# Altium schematic sheet 1_POWER.SchDoc
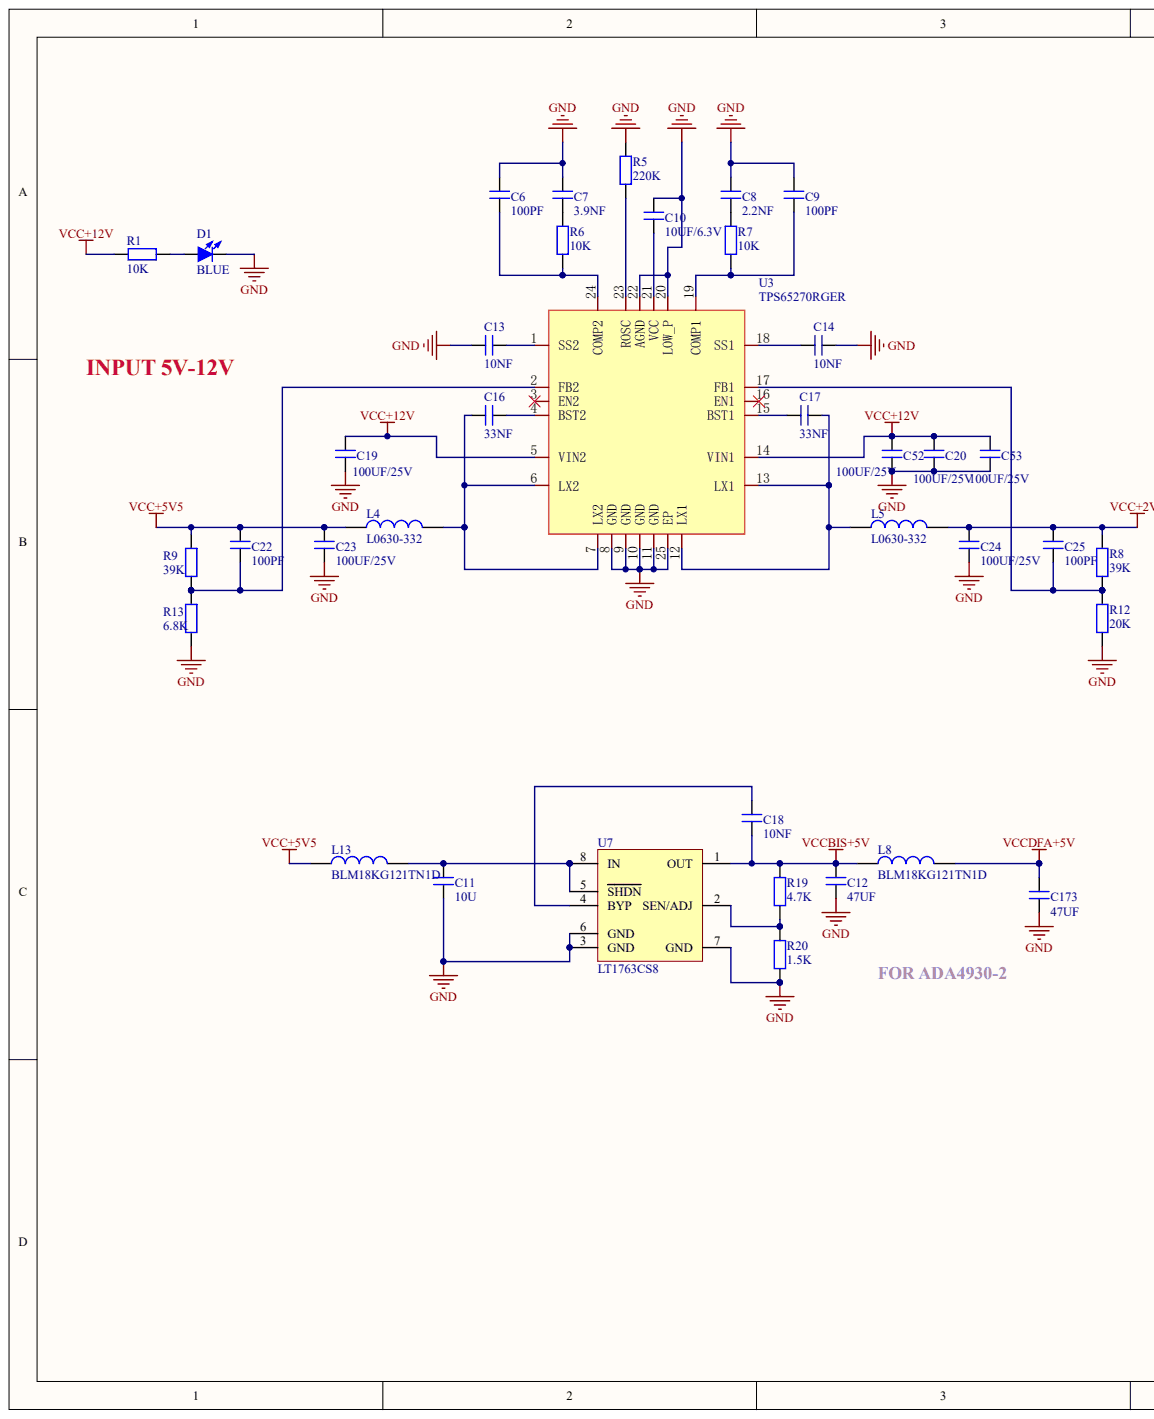
[diagram p0: 1_POWER.SchDoc, left]
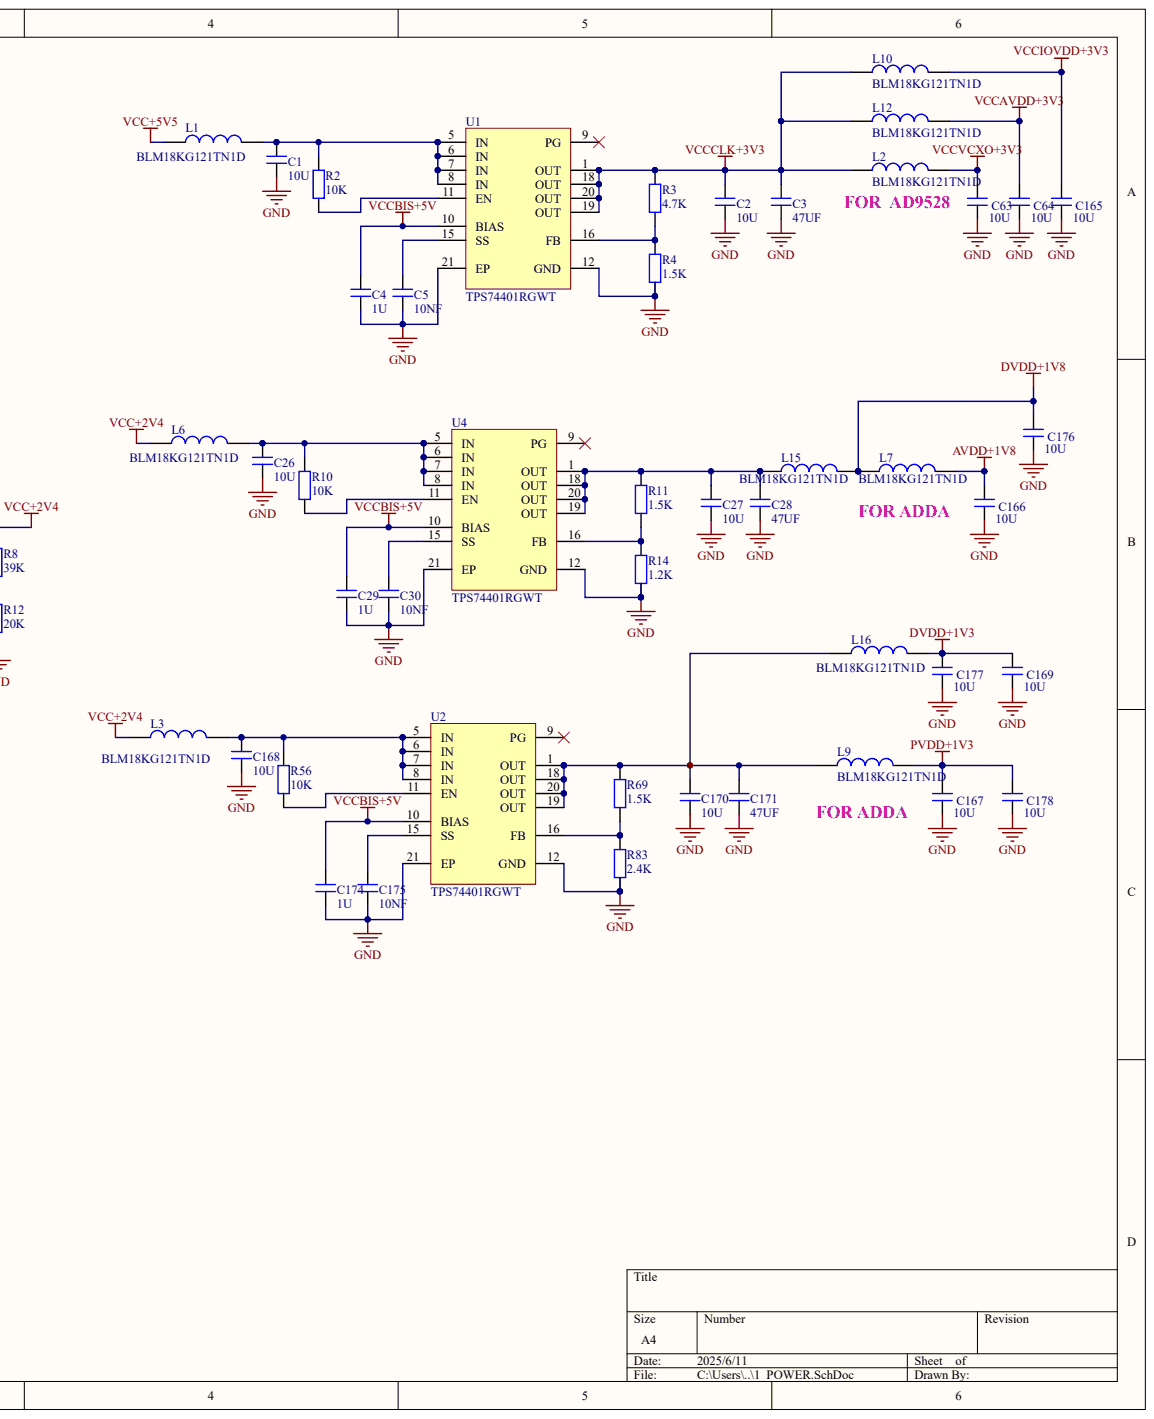
[diagram p1: 1_POWER.SchDoc, right]

## Parts
C1  10U
C2  10U
C3  47UF
C4  1U
C5  10NF
C6  100PF
C7  3.9NF
C8  2.2NF
C9  100PF
C10  10UF/6.3V
C11  10U
C12  47UF
C13  10NF
C14  10NF
C16  33NF
C17  33NF
C18  10NF
C19  100UF/25V
C20  100UF/25V
C22  100PF
C23  100UF/25V
C24  100UF/25V
C25  100PF
C26  10U
C27  10U
C28  47UF
C29  1U
C30  10NF
C52  100UF/25V
C53  100UF/25V
C63  10U
C64  10U
C165  10U
C166  10U
C167  10U
C168  10U
C169  10U
C170  10U
C171  47UF
C173  47UF
C174  1U
C175  10NF
C176  10U
C177  10U
C178  10U
D1  BLUE
L1  BLM18KG121TN1D
L2  BLM18KG121TN1D
L3  BLM18KG121TN1D
L4  L0630-332
L5  L0630-332
L6  BLM18KG121TN1D
L7  BLM18KG121TN1D
L8  BLM18KG121TN1D
L9  BLM18KG121TN1D
L10  BLM18KG121TN1D
L12  BLM18KG121TN1D
L13  BLM18KG121TN1D
L15  BLM18KG121TN1D
L16  BLM18KG121TN1D
R1  10K
R2  10K
R3  4.7K
R4  1.5K
R5  220K
R6  10K
R7  10K
R8  39K
R9  39K
R10  10K
R11  1.5K
R12  20K
R13  6.8K
R14  1.2K
R19  4.7K
R20  1.5K
R56  10K
R69  1.5K
R83  2.4K
U1  TPS74401RGWT
U2  TPS74401RGWT
U3  TPS65270RGER
U4  TPS74401RGWT
U7  LT1763CS8

## Nets
AVDD+1V8: C166.2 L7.2
DVDD+1V3: C169.2 C177.2 L16.2
DVDD+1V8: C176.2 L7.1 L15.2
GND: C1.2 C2.1 C3.1 C4.2 C5.2 C6.2 C7.2 C8.2 C9.2 C10.1 C11.2 C12.1 C13.1 C14.2 C19.1 C20.1 C23.2 C24.2 C26.2 C27.1 C28.1 C29.2 C30.2 C52.1 C53.1 C63.1 C64.1 C165.1 C166.1 C167.1 C168.2 C169.1 C170.1 C171.1 C173.1 C174.2 C175.2 C176.1 C177.1 C178.1 D1.2 R4.1 R5.2 R12.1 R13.1 R14.1 R20.1 R83.1 U1.12(GND) U1.21(EP) U2.12(GND) U2.21(EP) U3.8(GND) U3.9(GND) U3.10(GND) U3.11(GND) U3.20(LOW_P) U3.22(AGND) U3.25(EP) U4.12(GND) U4.21(EP) U7.3(GND) U7.6(GND) U7.7(GND)
PVDD+1V3: C167.2 C178.2 L9.2
VCC+2V4: C24.1 C25.2 L3.1 L5.1 L6.1 R8.2
VCC+5V5: C22.2 C23.1 L1.1 L4.1 L13.1 R9.2
VCC+12V: C19.2 C20.2 C52.2 C53.2 R1.1 U3.5(VIN2) U3.14(VIN1)
VCCAVDD+3V3: C64.2 L12.2
VCCBIS+5V: C4.1 C12.2 C18.1 C29.1 C174.1 L8.1 R19.2 U1.10(BIAS) U2.10(BIAS) U4.10(BIAS) U7.1(OUT)
VCCCLK+3V3: C2.2 C3.2 L2.1 L10.1 L12.1 R3.2 U1.1(OUT) U1.18(OUT) U1.19(OUT) U1.20(OUT)
VCCDFA+5V: C173.2 L8.2
VCCIOVDD+3V3: C165.2 L10.2
VCCVCXO+3V3: C63.2 L2.2
NetC1_1: C1.1 L1.2 R2.2 U1.5(IN) U1.6(IN) U1.7(IN) U1.8(IN)
NetC5_1: C5.1 U1.15(SS)
NetC6_1: C6.1 R6.1 U3.24(COMP2)
NetC7_1: C7.1 R6.2
NetC8_1: C8.1 R7.2
NetC9_1: C9.1 R7.1 U3.19(COMP1)
NetC10_2: C10.2 U3.21(VCC)
NetC11_1: C11.1 L13.2 U7.5(SHDN) U7.8(IN)
NetC13_2: C13.2 U3.1(SS2)
NetC14_1: C14.1 U3.18(SS1)
NetC16_1: C16.1 L4.2 U3.6(LX2) U3.7(LX2)
NetC16_2: C16.2 U3.4(BST2)
NetC17_1: C17.1 L5.2 U3.12(LX1) U3.13(LX1)
NetC17_2: C17.2 U3.15(BST1)
NetC18_2: C18.2 U7.4(BYP)
NetC22_1: C22.1 R9.1 R13.2 U3.2(FB2)
NetC25_1: C25.1 R8.1 R12.2 U3.17(FB1)
NetC26_1: C26.1 L6.2 R10.2 U4.5(IN) U4.6(IN) U4.7(IN) U4.8(IN)
NetC27_2: C27.2 C28.2 L15.1 R11.2 U4.1(OUT) U4.18(OUT) U4.19(OUT) U4.20(OUT)
NetC30_1: C30.1 U4.15(SS)
NetC168_1: C168.1 L3.2 R56.2 U2.5(IN) U2.6(IN) U2.7(IN) U2.8(IN)
NetC170_2: C170.2 C171.2 L9.1 L16.1 R69.2 U2.1(OUT) U2.18(OUT) U2.19(OUT) U2.20(OUT)
NetC175_1: C175.1 U2.15(SS)
NetD1_1: D1.1 R1.2
NetR2_1: R2.1 U1.11(EN)
NetR3_1: R3.1 R4.2 U1.16(FB)
NetR5_1: R5.1 U3.23(ROSC)
NetR10_1: R10.1 U4.11(EN)
NetR11_1: R11.1 R14.2 U4.16(FB)
NetR19_1: R19.1 R20.2 U7.2(SEN/ADJ)
NetR56_1: R56.1 U2.11(EN)
NetR69_1: R69.1 R83.2 U2.16(FB)

Not connected: U1 9(PG); U2 9(PG); U3 3(EN2) 16(EN1); U4 9(PG)

## Library data of the parts
D1 = (no symbol name): BOM名称: 贴片LED; 卖家免费查找封装，联系售后: 唯一出售店铺网址https://shop463601444.taobao.com; : 其他店铺购入均为假冒，请立即申请退款，本店奖励2~5元现金
R1-R14 R19 R20 R56 R69 R83 = (no symbol name): BOM名称: 贴片电阻; : 联系淘宝ID：黄晶宝石，获取更新版封装库; : 唯一出售店铺网址https://shop463601444.taobao.com
C1-C14 C16-C20 C22-C30 C52 C53 C63 C64 C165-C171 C173-C178 L1-L10 L12 L13 L15 L16 U1-U4 U7 = (no symbol name)
  C1-C14 C16-C20 C22-C30 C52 C53 C63 C64 C165-C171 C173-C178 L1-L10 L12 L13 L15 L16: : 联系淘宝ID：黄晶宝石，获取更新版封装库; : 唯一出售店铺网址https://shop463601444.taobao.com
